# Altium schematic sheet Nixie_TUBES_v2.SchDoc(Nixie_TUBES_v2)
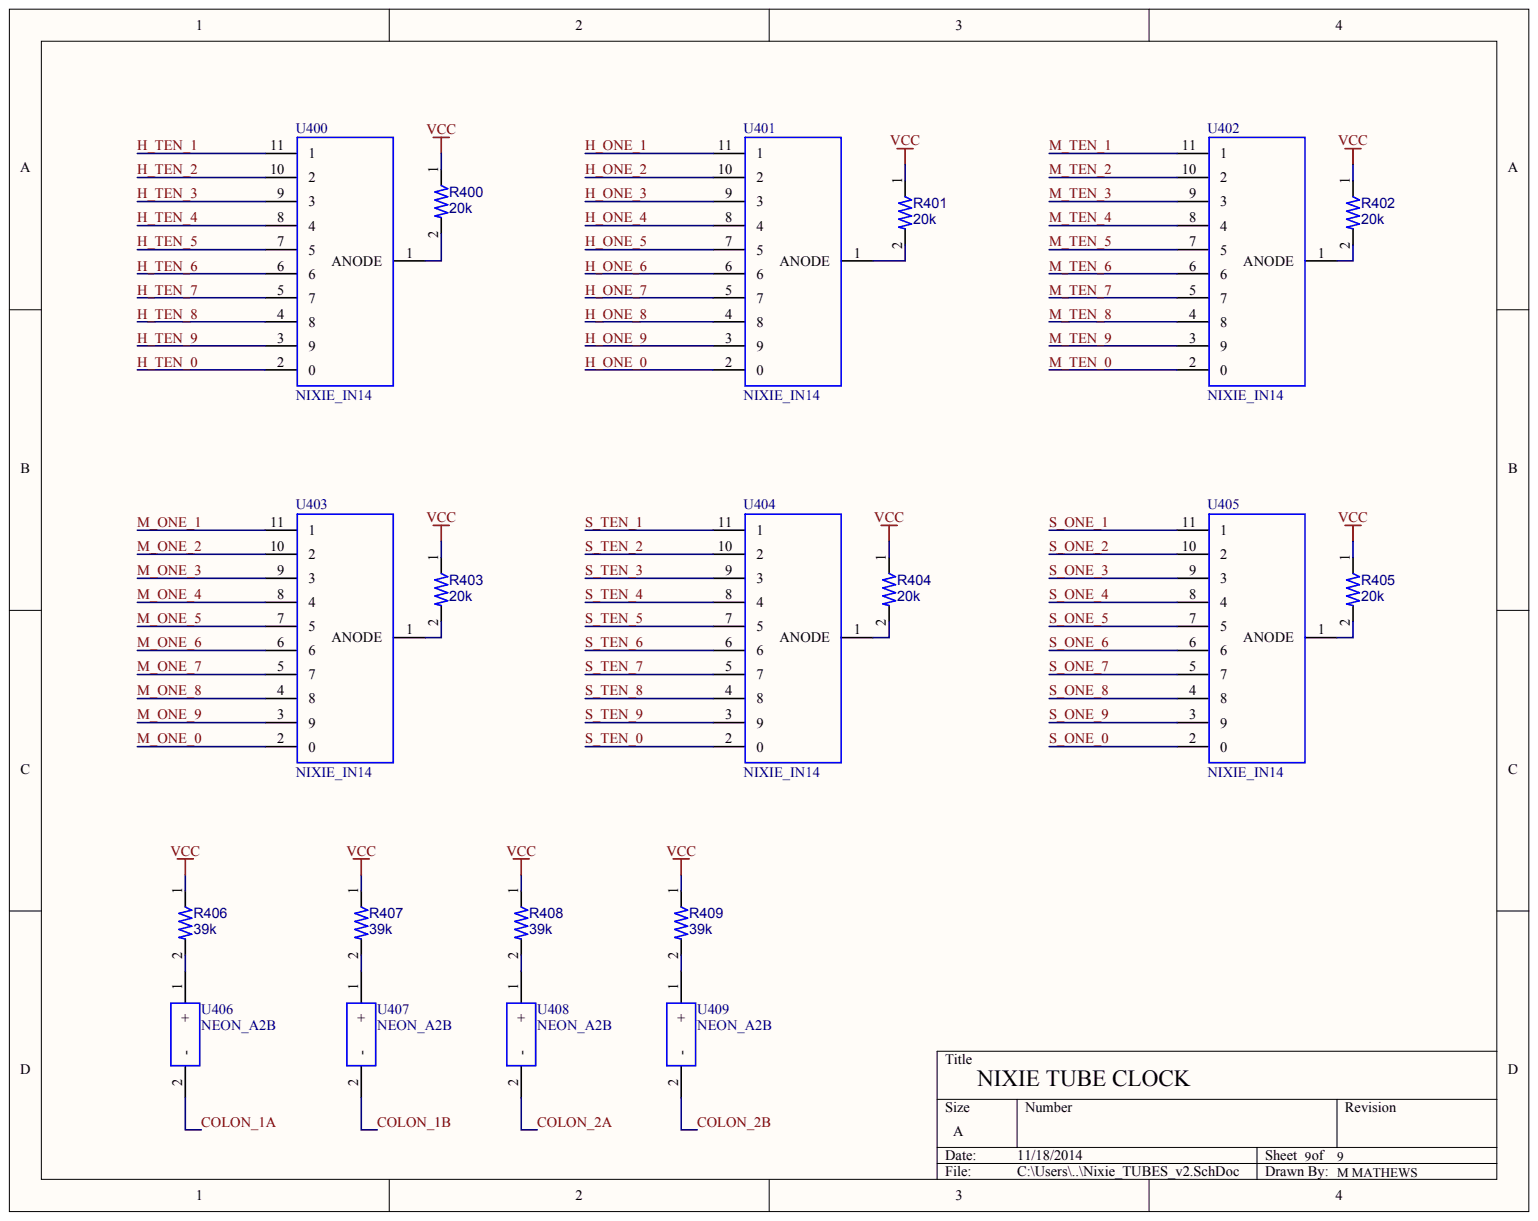
[diagram p0: Nixie_TUBES_v2.SchDoc]

## Parts
R400  value 20k  (hidden comment: RES)
R401  value 20k  (hidden comment: RES)
R402  value 20k  (hidden comment: RES)
R403  value 20k  (hidden comment: RES)
R404  value 20k  (hidden comment: RES)
R405  value 20k  (hidden comment: RES)
R406  value 39k  (hidden comment: RES)
R407  value 39k  (hidden comment: RES)
R408  value 39k  (hidden comment: RES)
R409  value 39k  (hidden comment: RES)
U400  NIXIE_IN14
U401  NIXIE_IN14
U402  NIXIE_IN14
U403  NIXIE_IN14
U404  NIXIE_IN14
U405  NIXIE_IN14
U406  NEON_A2B
U407  NEON_A2B
U408  NEON_A2B
U409  NEON_A2B

## Nets
VCC: R400.1 R401.1 R402.1 R403.1 R404.1 R405.1 R406.1 R407.1 R408.1 R409.1
COLON_1A: U406.2
COLON_1B: U407.2
COLON_2A: U408.2
COLON_2B: U409.2
H_ONE_0: U401.2
H_ONE_1: U401.11
H_ONE_2: U401.10
H_ONE_3: U401.9
H_ONE_4: U401.8
H_ONE_5: U401.7
H_ONE_6: U401.6
H_ONE_7: U401.5
H_ONE_8: U401.4
H_ONE_9: U401.3
H_TEN_0: U400.2
H_TEN_1: U400.11
H_TEN_2: U400.10
H_TEN_3: U400.9
H_TEN_4: U400.8
H_TEN_5: U400.7
H_TEN_6: U400.6
H_TEN_7: U400.5
H_TEN_8: U400.4
H_TEN_9: U400.3
M_ONE_0: U403.2
M_ONE_1: U403.11
M_ONE_2: U403.10
M_ONE_3: U403.9
M_ONE_4: U403.8
M_ONE_5: U403.7
M_ONE_6: U403.6
M_ONE_7: U403.5
M_ONE_8: U403.4
M_ONE_9: U403.3
M_TEN_0: U402.2
M_TEN_1: U402.11
M_TEN_2: U402.10
M_TEN_3: U402.9
M_TEN_4: U402.8
M_TEN_5: U402.7
M_TEN_6: U402.6
M_TEN_7: U402.5
M_TEN_8: U402.4
M_TEN_9: U402.3
S_ONE_0: U405.2
S_ONE_1: U405.11
S_ONE_2: U405.10
S_ONE_3: U405.9
S_ONE_4: U405.8
S_ONE_5: U405.7
S_ONE_6: U405.6
S_ONE_7: U405.5
S_ONE_8: U405.4
S_ONE_9: U405.3
S_TEN_0: U404.2
S_TEN_1: U404.11
S_TEN_2: U404.10
S_TEN_3: U404.9
S_TEN_4: U404.8
S_TEN_5: U404.7
S_TEN_6: U404.6
S_TEN_7: U404.5
S_TEN_8: U404.4
S_TEN_9: U404.3
NetR400_2: R400.2 U400.1(ANODE)
NetR401_2: R401.2 U401.1(ANODE)
NetR402_2: R402.2 U402.1(ANODE)
NetR403_2: R403.2 U403.1(ANODE)
NetR404_2: R404.2 U404.1(ANODE)
NetR405_2: R405.2 U405.1(ANODE)
NetR406_2: R406.2 U406.1
NetR407_2: R407.2 U407.1
NetR408_2: R408.2 U408.1
NetR409_2: R409.2 U409.1

## Library data of the parts
R400-R405 = (no symbol name): Manufacturer: Panasonic; Manufacturer Part Number: ERJ-8GEYJ203V; Tolerance: 5%; Voltage: -; Revision: A; Cost: 0.0276; Supplier 1: Digi-key; Supplier Part Number 1: P20KECT-ND; Component Kind: Standard; IPC Pattern: 1206
R406-R409 = (no symbol name): Manufacturer: Panasonic; Manufacturer Part Number: ERJ-8GEYJ393V; Tolerance: 5%; Voltage: -; Revision: A; Cost: 0.0276; Supplier 1: Digi-key; Supplier Part Number 1: P39KECT-ND; Component Kind: Standard; IPC Pattern: 1206
U400-U405 = (no symbol name): Manufacturer: Soviet Union; Manufacturer Part Number: IN-14; Value: 1mA; Voltage: 170V; Cost: 4.00; IPC Pattern: Nixie; Supplier 1: ebay; Supplier Part Number 1: IN-14; Tolerance: -
U406-U409 = (no symbol name): Manufacturer: VCC; Manufacturer Part Number: C2A; Voltage: 150V; Cost: 0.491; IPC Pattern: TH; Supplier 1: Digi-key; Supplier Part Number 1: C2A-ND; Tolerance: -; Value: -
